# Altium schematic sheet CAN.SchDoc
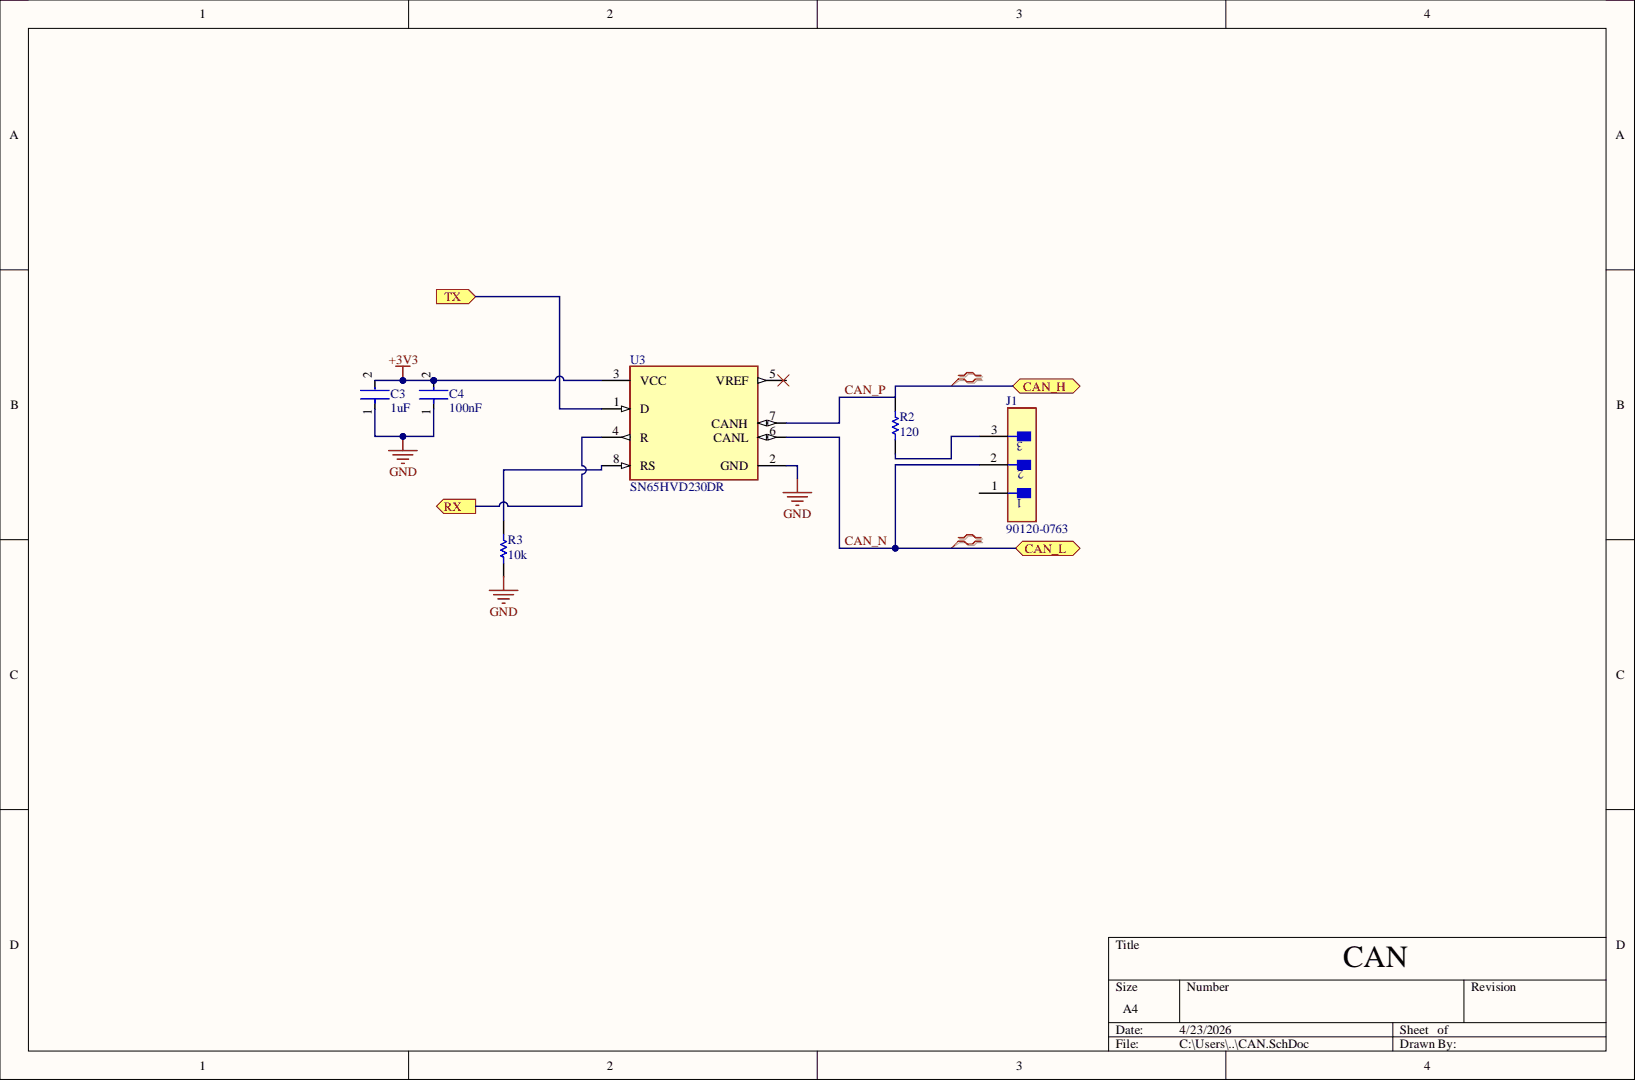
[diagram p0: CAN.SchDoc]

## Parts
C3  1uF  [FP-0603-L_1_6_0_15-W_0_8-IPC_B]
C4  100nF  [FP-0603-L_1_6_0_15-W_0_8-IPC_B]
J1  90120-0763  [FP-90120-0763-MFG]
R2  120  [RESC1608X55X30ML15T15]
R3  10k  [RESC1608X55X30ML15T15]
U3  SN65HVD230DR  Case/Package: D  [FP-D0008A-IPC_A]

## Ports
CAN_H, CAN_L, RX, TX

## Nets
+3V3: C3.2 C4.2 U3.3(VCC)
GND: C3.1 C4.1 U3.2(GND)
CAN_N: J1.2 U3.6(CANL) ; port CAN_L
CAN_P: R2.2 U3.7(CANH) ; port CAN_H
RX: U3.4(R) ; port RX
TX: U3.1(D) ; port TX
NetJ1_1: J1.1
NetJ1_3: J1.3 R2.1
NetR3_1: R3.1
NetR3_2: R3.2 U3.8(RS)
NetU3_5: U3.5(VREF)

## Library data of the parts
C3 C4 = CMP-2000-07531-4: Ceramic Capacitor General Use 1uF ±10% 50V X7R 0603; Capacitance: 1uF; Case Code (Imperial): 0603; Case Code (Metric): 1608; Case/Package: 0603; Component Kind: Standard; Construction: Flat; Depth: 0.8mm; Dielectric: X7R; Halogen Free: Halogen Free; Height: 0.8mm; Length: 1.6mm; Library Name: Altium Content Vault; Max Operating Temperature: 125°C; Min Operating Temperature: -55°C; Mount: Surface Mount; Package Quantity: 1; Packaging: Tape and Reel; Radiation Hardening: No; RoHS Compliant: Yes; Series: M; Termination: SMD/SMT; Thickness: 0.037inch; Tolerance: 10%; Voltage Rating: 50V; Voltage Rating (DC): 50V; Width: 0.031inch
J1 = CMP-04776-000084-1: CONN HEADER VERT 3POS 2.54MM; Manufacturer: Molex; Conn Gender: Male; Conn Orientation: Vertical; Current Rating: 3A; Number Of Contacts: 3; Voltage Rating: 350V; Automotive: No; Category: Conn; Component Kind: Standard; ComponentLink2Description: Datasheet; ComponentLink2URL: https://www.molex.com/webdocs/datasheets/pdf/en-us/0901200763_PCB_HEADERS.pdf; Contact Material: Gold,Nickel; Device Class L1: Connectors; Device Class L2: Headers and Wire Housings; Device Class L3: unset; Digikey Description: CONN HEADER VERT 3POS 2.54MM; Footprint Url: https://www.molex.com/pdm_docs/sd/901200763_sd.pdf; Height: 5.8mm; Lead Free: Yes; Library Name: Altium Content Vault; Manufacturer Part Number: 90120-0763; Mouser Description: Board-To-Board Connector, C-Grid III 90120 Series, Through Hole, Header, 3, 2.54 mm; Number Of Rows: 1; Octopart: https://octopart.com/90120-0763-molex-655433; Package: 90120-0763; Pitch: 2.54mm; RoHS: TRUE; Temperature: -55°C to +125°C; Temperature Range High: +125°C; Temperature Range Low: -55°C
R2 R3 = CMP-1012-00320-3: Chip Resistor, 22 Ohm, +/- 1%, 0.1 W, -55 to 155 degC, 0603 (1608 Metric), RoHS, Tape and Reel; Component Kind: Standard; ComponentLink1Description: Manufacturer URL; ComponentLink1URL: http://www.panasonic.com/; ComponentLink2Description: Datasheet; ComponentLink2URL: http://industrial.panasonic.com/cdbs/www-data/pdf/RDA0000/AOA0000C86.pdf; DatasheetVersion: Apr-15; Library Name: Altium Content Vault; PackageDescription: 2-Pin Surface Mount Device, Body 1.6 x 0.85 mm; PackageReference: 0603
U3 = CMP-0315-00015-3: IC TRANSCEIVER HALF 1/1 8SOIC; Data Rate: 1Mbps; Max Supply Current: 17mA; Max Supply Voltage: 3.6V; Min Supply Voltage: 3V; Number of Drivers: 1; Number of I/Os: 2; Operating Supply Voltage: 3.3V; Component Kind: Standard; Contact Plating: Gold; Lead Free: Lead Free; Library Name: Altium Content Vault; Max Operating Temperature: 85°C; Min Operating Temperature: -40°C; Nominal Supply Current: 17mA; Number of Pins: 8; Number of Receive Buffers: 1; Number of Receivers: 1; Number of Transceivers: 1; Number of Transmit Buffers: 1; Package Quantity: 1; Packaging: Tape and Reel; Radiation Hardening: No; REACH SVHC: No SVHC; Termination: SMD/SMT; Weight: 0.002561oz
